# Altium schematic sheet nguyenly.SchDoc
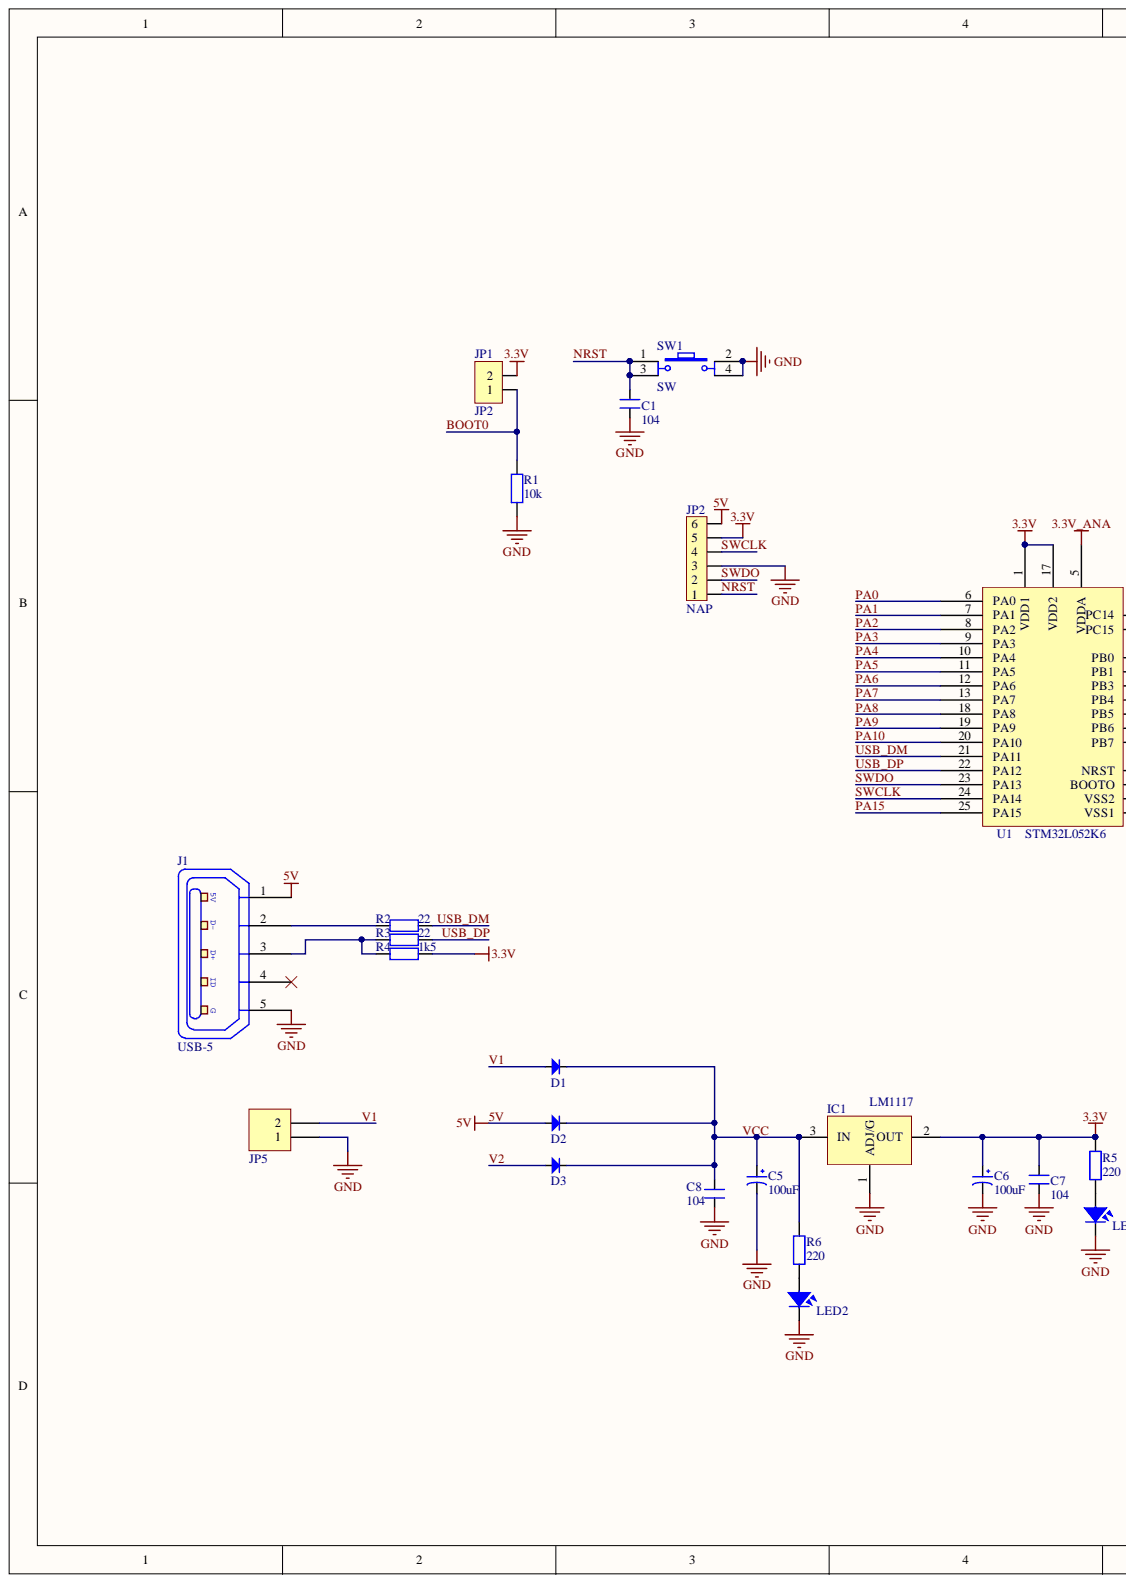
[diagram p0: nguyenly.SchDoc, left]
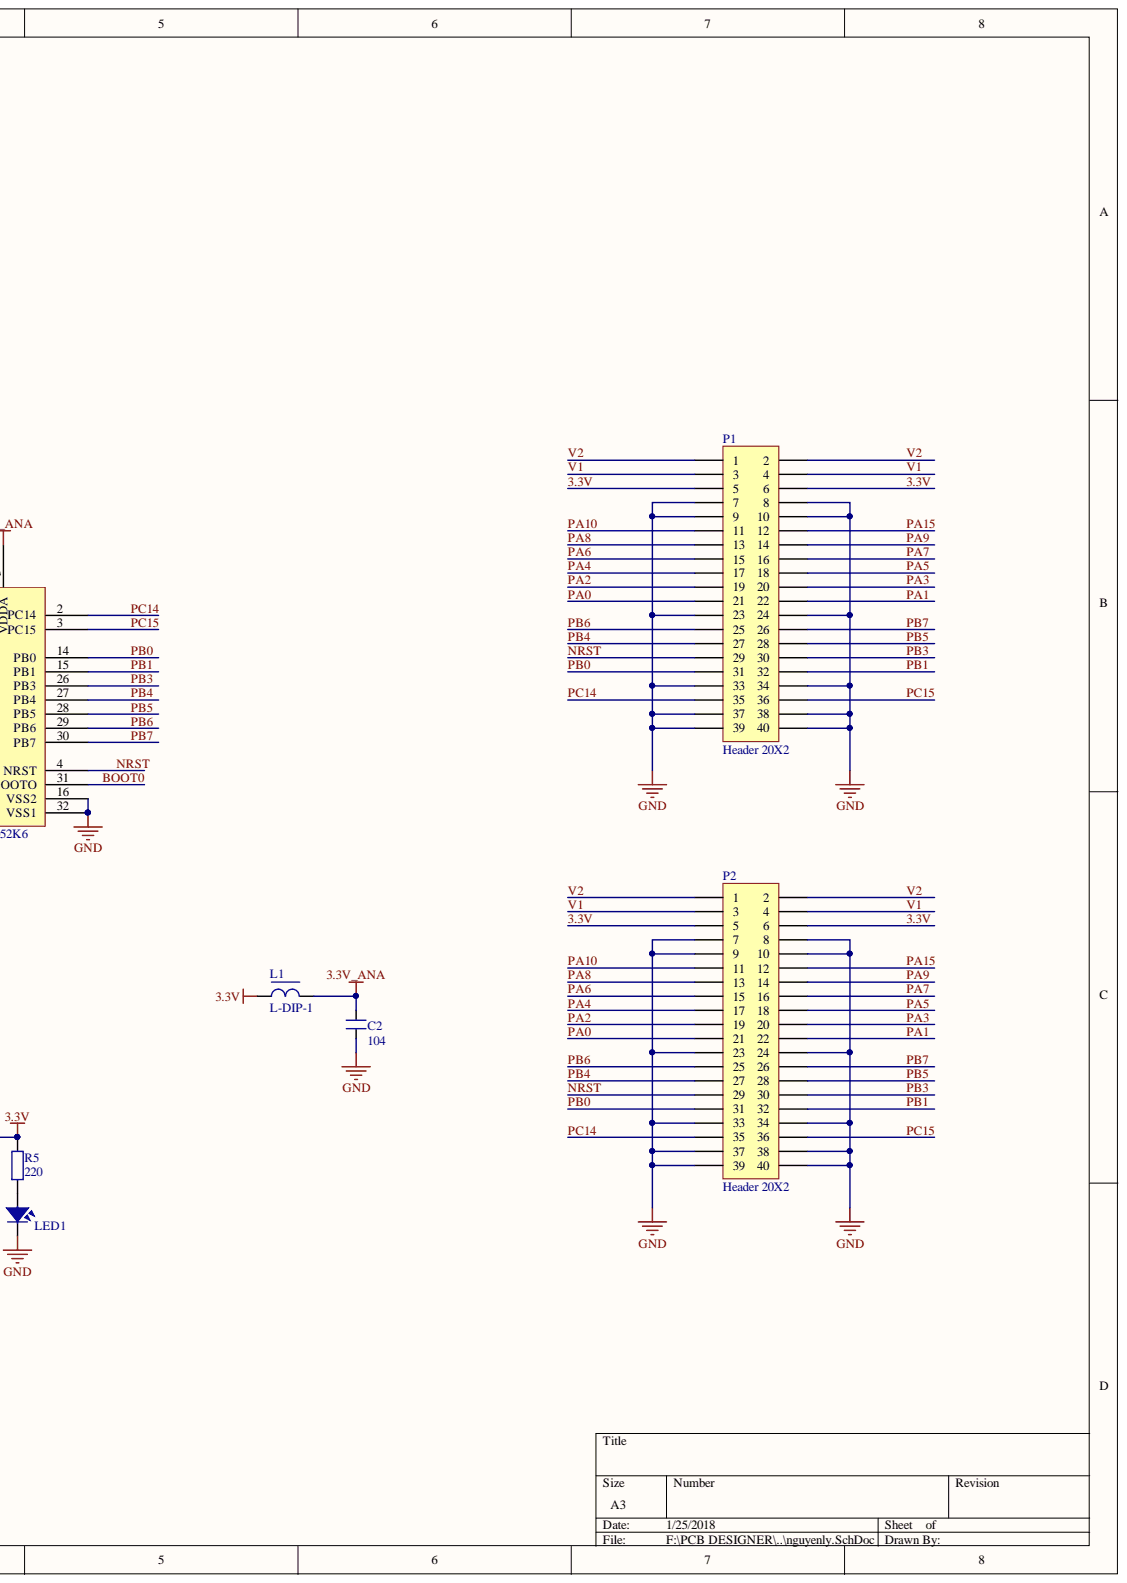
[diagram p1: nguyenly.SchDoc, right]

## Parts
C1  104  [SM-CAP-0805]
C2  104  [SM-CAP-0805]
C5  100uF  [CP1/2]
C6  100uF  [CP1/2]
C7  104  [SM-CAP-0805]
C8  104  [SM-CAP-0805]
D1  Diode SMD  [SM-6728N]  (value not drawn)
D2  Diode SMD  [SM-6728N]  (value not drawn)
D3  Diode SMD  [SM-6728N]  (value not drawn)
IC1  LM1117  [SOT223-4N]
J1  USB-5  [USB-M2]
JP1  JP2  [JP2-C1T]
JP2  NAP  [JP6]
JP5  Header 2_1  [HED2]  (value not drawn)
L1  L-DIP-1  [L/0.4]
LED1  LED  [SM-LED-0805-Red]
LED2  LED  [SM-LED-0805-Red]
P1  Header 20X2  [HDR2X20]
P2  Header 20X2  [HDR2X20]
R1  10k  [SM-RES-0805]
R2  22  [SM-RES-0805]
R3  22  [SM-RES-0805]
R4  1k5  [SM-RES-0805]
R5  220  [SM-RES-0805]
R6  220  [SM-RES-0805]
SW1  SW  [SW4]
U1  STM32L052K6  [TQFP32]

## Nets
3.3V_ANA: C2.2 L1.2 U1.5(VDDA)
GND: C1.1 C2.1 C5.2 C6.2 C7.1 C8.1 IC1.1 J1.5 JP2.3 JP5.1 LED1.2 LED2.2 P1.7 P1.8 P1.9 P1.10 P1.23 P1.24 P1.33 P1.34 P1.37 P1.38 P1.39 P1.40 P2.7 P2.8 P2.9 P2.10 P2.23 P2.24 P2.33 P2.34 P2.37 P2.38 P2.39 P2.40 R1.1 SW1.2 SW1.4 U1.16(VSS2) U1.32(VSS1)
3.3V: C6.1 C7.2 IC1.2 JP1.2 JP2.5 L1.1 P1.5 P1.6 P2.5 P2.6 R4.1 R5.2 U1.1(VDD1) U1.17(VDD2)
5V: D2.1 J1.1 JP2.6
BOOT0: JP1.1 R1.2 U1.31(BOOTO)
NRST: C1.2 JP2.1 P1.29 P2.29 SW1.1 SW1.3 U1.4(NRST)
PA0: P1.21 P2.21 U1.6(PA0)
PA1: P1.22 P2.22 U1.7(PA1)
PA2: P1.19 P2.19 U1.8(PA2)
PA3: P1.20 P2.20 U1.9(PA3)
PA4: P1.17 P2.17 U1.10(PA4)
PA5: P1.18 P2.18 U1.11(PA5)
PA6: P1.15 P2.15 U1.12(PA6)
PA7: P1.16 P2.16 U1.13(PA7)
PA8: P1.13 P2.13 U1.18(PA8)
PA9: P1.14 P2.14 U1.19(PA9)
PA10: P1.11 P2.11 U1.20(PA10)
PA15: P1.12 P2.12 U1.25(PA15)
PB0: P1.31 P2.31 U1.14(PB0)
PB1: P1.32 P2.32 U1.15(PB1)
PB3: P1.30 P2.30 U1.26(PB3)
PB4: P1.27 P2.27 U1.27(PB4)
PB5: P1.28 P2.28 U1.28(PB5)
PB6: P1.25 P2.25 U1.29(PB6)
PB7: P1.26 P2.26 U1.30(PB7)
PC14: P1.35 P2.35 U1.2(PC14)
PC15: P1.36 P2.36 U1.3(PC15)
SWCLK: JP2.4 U1.24(PA14)
SWDO: JP2.2 U1.23(PA13)
USB_DM: R2.1 U1.21(PA11)
USB_DP: R3.1 U1.22(PA12)
V1: D1.1 JP5.2 P1.3 P1.4 P2.3 P2.4
V2: D3.1 P1.1 P1.2 P2.1 P2.2
VCC: C5.1 C8.2 D1.2 D2.2 D3.2 IC1.3 R6.2
NetJ1_2: J1.2 R2.2
NetJ1_3: J1.3 R3.2 R4.2
NetLED1_1: LED1.1 R5.1
NetLED2_1: LED2.1 R6.1

Not connected: J1 4

## Library data of the parts
C1 C2 C7 C8 = CN1: Cap np  1pF - 472pF; Value: 100pF; Component Kind: Standard; Library Name: syhaunguyen.IntLib
C5 C6 = CP1: Cap P  0,1uF < 22uF , 16 - 50V; Description 1: 10 => 220uF/6,3V/10V - 10uF => 100uF/16V; Description 2: 0,1uF => 33uF/50V - 10uF => 22uF/63V; Description 3: 0,47uF => 10uF/100V; Value: 10uF/50V; Component Kind: Standard; Library Name: syhaunguyen.IntLib
D1-D3 = Diode SMD: Default Diode; Code - JEDEC: DO-214-AB; Value: 1N5007; Component Kind: Standard; Library Name: LIBRARY.IntLib; Published: 8-Jun-2000; Revision: July-2002: Re-released for DXP Platform.; Publisher: Altium Limited
IC1 = LM1117: Available in 1.8V, 2.5V, 2.85V, 3.3V, 5V, and  Adjustable Versions; Value: LM317; Component Kind: Standard; Library Name: syhaunguyen.IntLib
J1 = USB-5: Manufacturer: FCI; Manufacturer Part Number: 10118193-0001LF; .Checked PCB: RF; .Checked SCH: MM; Connector Type: USB - micro B; Gender: Receptacle; Number of Contacts: 5; Specifications: USB 2.0; Category: Connectors, Interconnects; Component Kind: Standard; ComponentLink1Description: http://portal.fciconnect.com/Comergent//fci/drawing/10118193.pdf; ComponentLink1URL: http://portal.fciconnect.com/Comergent//fci/drawing/10118193.pdf; Features: Shielded, Solder Retention; Ingress Protection: -; Library Name: LIBRARY.IntLib; Mounting Type: Surface Mount, Right Angle, Horizontal; Packaging: Cut Tape (CT); Pricing 1: 1=0.46, 10=0.433, 25=0.3712, 50=0.3156, 100=0.3032, 250=0.27224, 500=0.25988 (USD); RoHS: RoHS Compliant; Stock 1: 28642; Supplier 1: Digi-Key; Supplier Part Number 1: 609-4616-1-ND; .Creator: MM
JP1 = JP2: WR-PHD 2.54 mm Angled Socket Header; Manufacturer: W¨¹rth Elektronik eiSos GmbH; partNo: 613002143121; ComponentLink1 Description: Manufacturer; ComponentLink2 Description: Datasheet; NoPins: 02; Component Kind: Standard; ComponentLink1URL: http://www.we-online.com; ComponentLink2URL: http://katalog.we-online.de/em/datasheet/6130xx143121.pdf; Created Date: 29 March 2013; Library Name: LIBRARY.IntLib; Created by: Karrer Zheng
JP2 = JP6: JP6 PIN; Value: JP7; Component Kind: Standard; Library Name: VioletofSun.SchLib
JP5 = Header 2_1: Header, 2-Pin; Manufacturer: Generic Components; Class: Connector; Component Kind: Standard; Value: Header 2; Library Name: syhaunguyen.IntLib
L1 = L-DIP-1: Inductor; Value: 150 mH; Component Kind: Standard; Library Name: LIBRARY.IntLib
LED1 LED2 = LED: LED Single colour Green and Red; Value: LED 3 ly Single Colour; Component Kind: Standard; Library Name: syhaunguyen.IntLib
P1 P2 = Header 20X2: Header, 20-Pin, Dual row; Component Kind: Standard; LatestRevisionDate: 17-Jul-2002; LatestRevisionNote: Re-released for DXP Platform.; Library Name: Miscellaneous Connectors.IntLib; Signal Integrity: Connector; Publisher: Altium Limited
R1-R6 = R1/4W: Resistor 1/4W; Value: R1/4W - 1K; Component Kind: Standard; Library Name: syhaunguyen.IntLib
SW1 = SW4: Switch button 4 pin; Value: Button chip 4 pin; Component Kind: Standard; Library Name: syhaunguyen.IntLib
U1 = STM32L052K6: Component Kind: Standard; Library Name: stm.SchLib
